# Altium schematic sheet SD-Socket.SchDoc(U_SD-Socket)
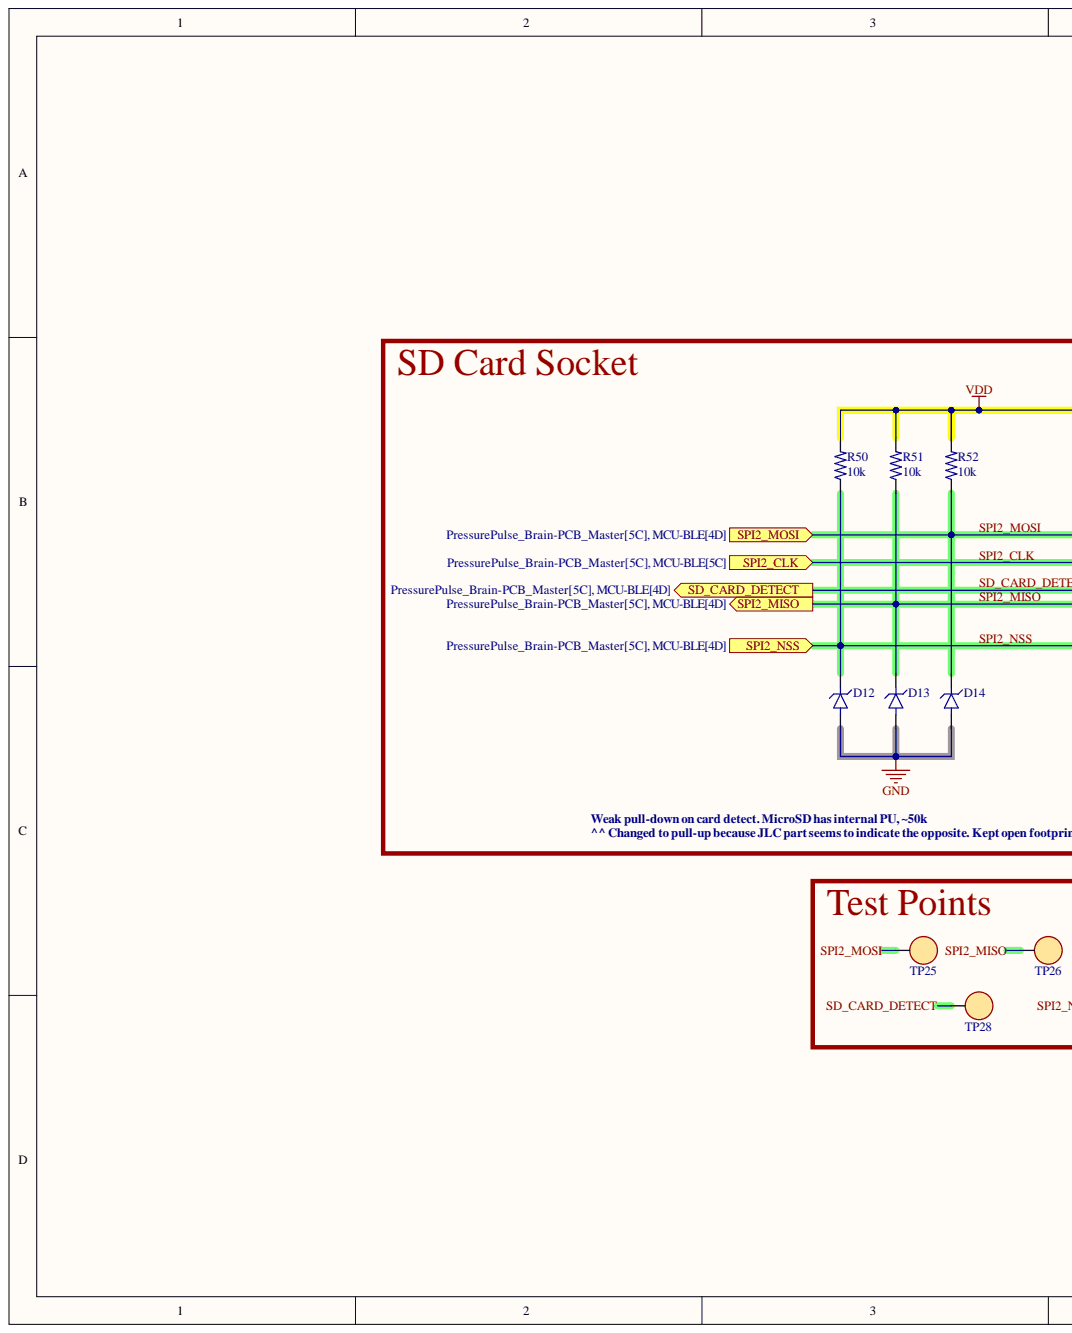
[diagram p0: SD-Socket.SchDoc, left]
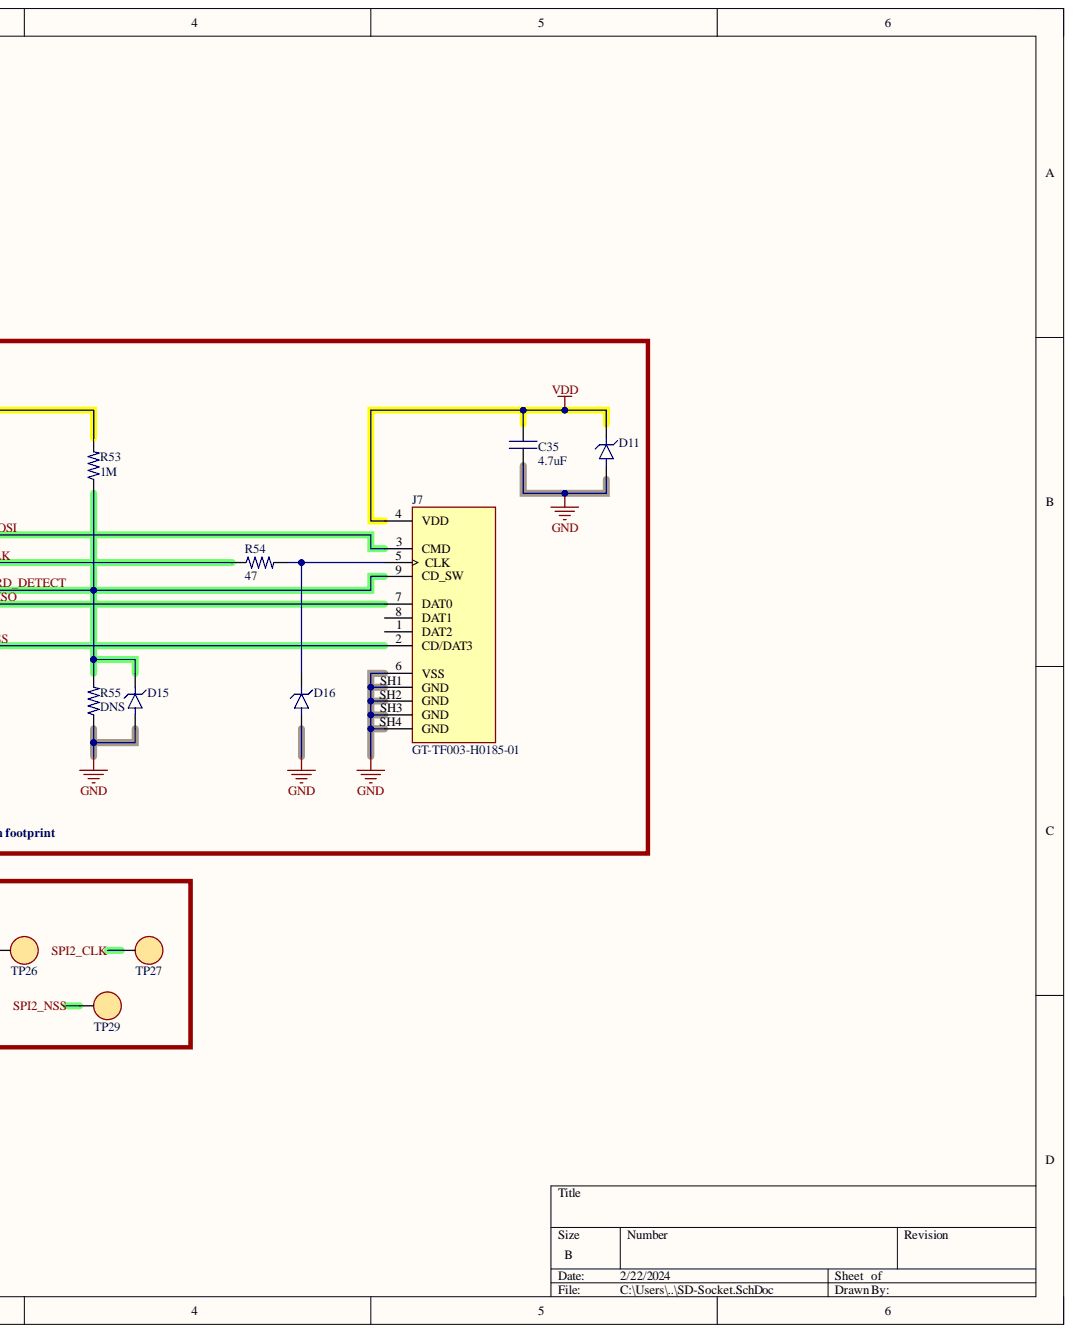
[diagram p1: SD-Socket.SchDoc, right]

## Parts
C35  value 4.7uF  (hidden comment: CAP)
D11  RCLAMP0521P.TCT  [RCLAMP0521P.TCT]  (value not drawn)
D12  RCLAMP0521P.TCT  [RCLAMP0521P.TCT]  (value not drawn)
D13  RCLAMP0521P.TCT  [RCLAMP0521P.TCT]  (value not drawn)
D14  RCLAMP0521P.TCT  [RCLAMP0521P.TCT]  (value not drawn)
D15  RCLAMP0521P.TCT  [RCLAMP0521P.TCT]  (value not drawn)
D16  RCLAMP0521P.TCT  [RCLAMP0521P.TCT]  (value not drawn)
J7  GT-TF003-H0185-01  [GTTF003H018501]
R50  value 10k  (hidden comment: RES)
R51  value 10k  (hidden comment: RES)
R52  value 10k  (hidden comment: RES)
R53  value 1M  (hidden comment: RES)
R54  value 47  (hidden comment: RES)
R55  value DNS  (hidden comment: RES)
TP25  RH-5019  [TP - RH-5019]  (value not drawn)
TP26  RH-5019  [TP - RH-5019]  (value not drawn)
TP27  RH-5019  [TP - RH-5019]  (value not drawn)
TP28  RH-5019  [TP - RH-5019]  (value not drawn)
TP29  RH-5019  [TP - RH-5019]  (value not drawn)

## Ports
SD_CARD_DETECT, SPI2_CLK, SPI2_MISO, SPI2_MOSI, SPI2_NSS

## Nets
GND: C35.1 D11.A D12.A D13.A D14.A D15.A D16.A J7.6(VSS) J7.SH1(GND) J7.SH2(GND) J7.SH3(GND) J7.SH4(GND) R55.1
VDD: C35.2 D11.K J7.4(VDD) R50.2 R51.2 R52.2 R53.2
SD_CARD_DETECT: D15.K J7.9(CD_SW) R53.1 R55.2 TP28.1 ; port SD_CARD_DETECT
SPI2_CLK: R54.1 TP27.1 ; port SPI2_CLK
SPI2_MISO: D13.K J7.7(DAT0) R51.1 TP26.1 ; port SPI2_MISO
SPI2_MOSI: D14.K J7.3(CMD) R52.1 TP25.1 ; port SPI2_MOSI
SPI2_NSS: D12.K J7.2(CD/DAT3) R50.1 TP29.1 ; port SPI2_NSS
NetD16_K: D16.K J7.5(CLK) R54.2
NetJ7_1: J7.1(DAT2)
NetJ7_8: J7.8(DAT1)

## Library data of the parts
C35 = CAP: Capacitor; Component Kind: Standard; Library Name: PressurePulse.SchLib
D11-D16 = TVS DIODE: 25V Clamp 4A (8/20µs) Ipp Tvs Diode Surface Mount SLP1006P2; MFR: Semtech Corporation; Type: SMD; Component Kind: Standard; Library Name: PressurePulse.SchLib; MFR PN: RCLAMP0521P.TCT; Value: RCLAMP0521P.TCT
J7 = SD-CONN-SMD_JLC: MFR: G-Switch; Component Kind: Standard; Library Name: PressurePulse.SchLib; MFR PN: GT-TF003-H0185-01; Type: SMD; Value: GT-TF003-H0185-01
R50-R55 = RES: Resistor; Component Kind: Standard; Library Name: PressurePulse.SchLib
TP25-TP29 = TEST POINT: MFR: ronghe; Component Kind: Standard; JLCPCB PN: C5199800; Library Name: PressurePulse.SchLib; MFR PN: RH-5019; Value: RH-5019
